# Altium schematic sheet ArduinoUnoShield.SchDoc(ArduinoUnoShield)
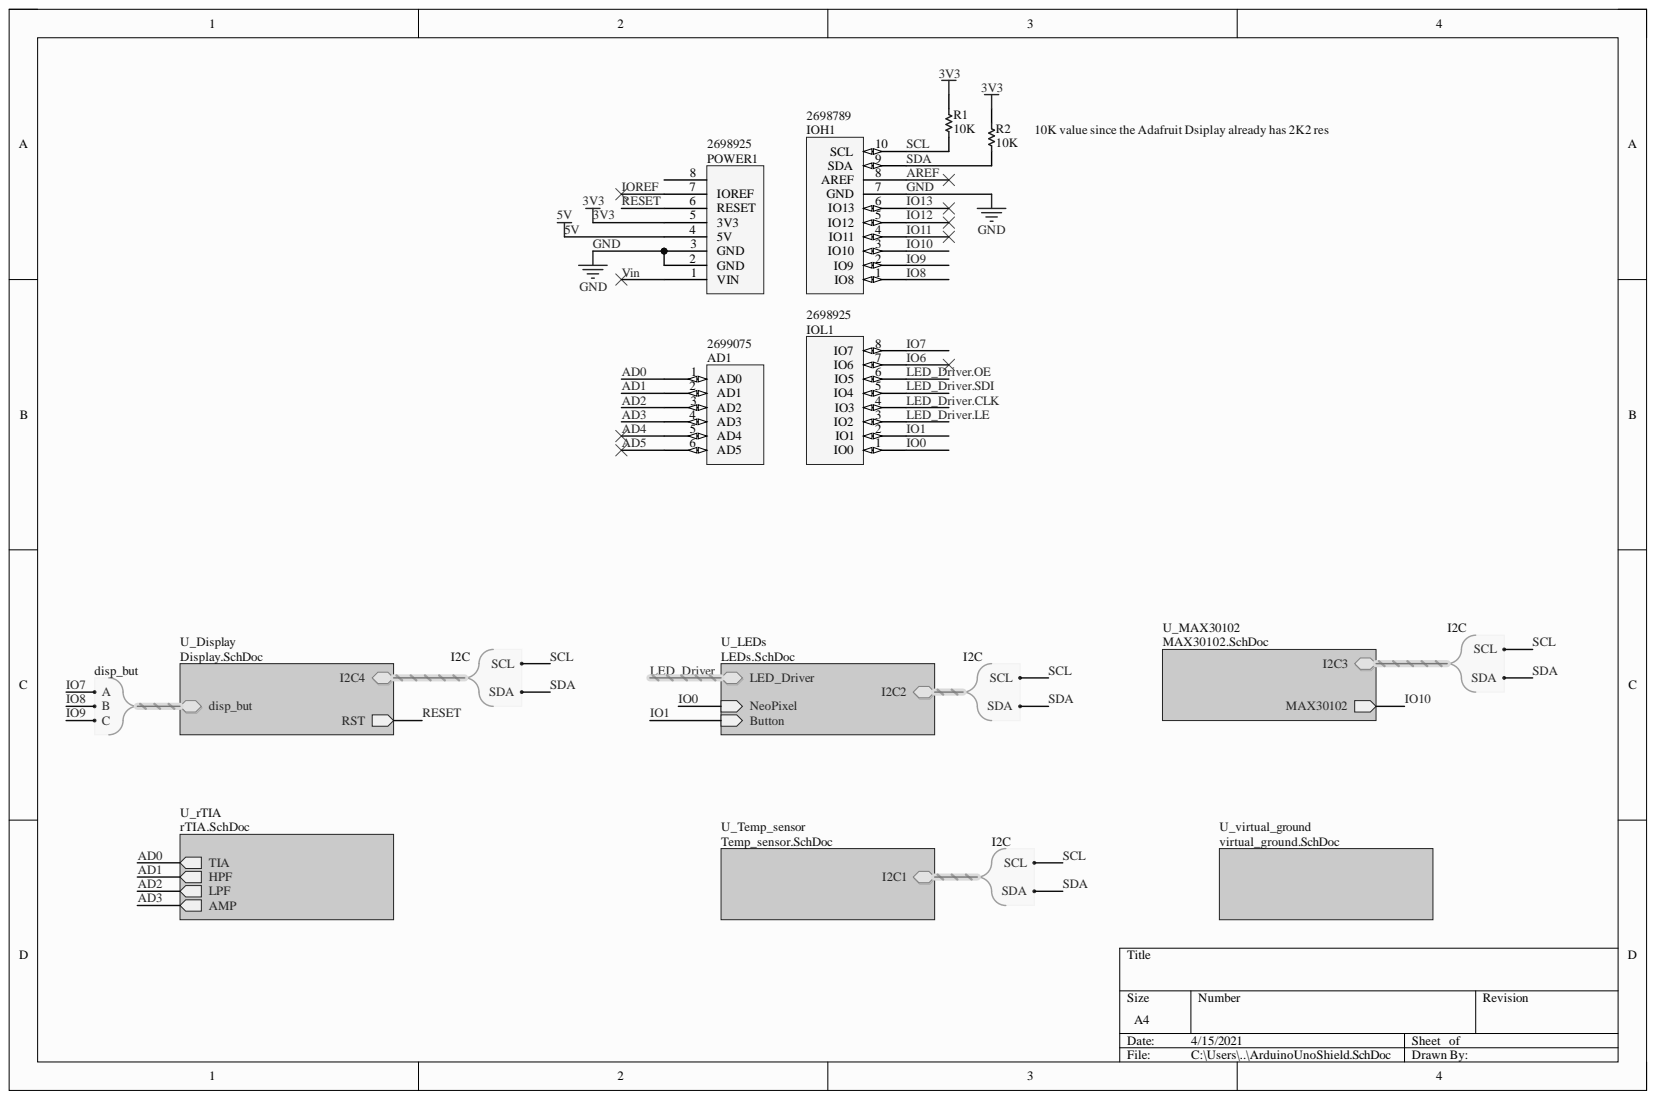
[diagram p0: ArduinoUnoShield.SchDoc]

## Parts
AD1  AD  Supplier Part Number 4: 2699075  [Header 6x1 8.5mm]
IOH1  IOH  Supplier Part Number 3: 2698789  [Header 10x1 8.5mm]
IOL1  IOL  Supplier Part Number 4: 2698925  [Header 8x1 8.5mm]
POWER1  Supplier Part Number 4: 2698925  [Header 8x1 8.5mm]  (hidden comment: POWER_UNO)
R1  10K  [RESC1609X50X30NL8T20]
R2  10K  [RESC1609X50X30NL8T20]

## Nets
3V3: POWER1.5(3V3) R1.2 R2.2
5V: POWER1.4(5V)
AD0: AD1.1(AD0)
AD1: AD1.2
AD2: AD1.3(AD2)
AD3: AD1.4(AD3)
AD4: AD1.5(AD4)
AD5: AD1.6(AD5)
AREF: IOH1.8(AREF)
GND: IOH1.7(GND) POWER1.2(GND) POWER1.3(GND)
IO0: IOL1.1(IO0)
IO1: IOL1.2(IO1)
IO6: IOL1.7(IO6)
IO7: IOL1.8(IO7)
IO8: IOH1.1(IO8)
IO9: IOH1.2(IO9)
IO10: IOH1.3(IO10)
IO11: IOH1.4(IO11)
IO12: IOH1.5(IO12)
IO13: IOH1.6(IO13)
IOREF: POWER1.7(IOREF)
LED_Driver.CLK: IOL1.4(IO3)
LED_Driver.LE: IOL1.3(IO2)
LED_Driver.OE: IOL1.6(IO5)
LED_Driver.SDI: IOL1.5(IO4)
RESET: POWER1.6(RESET)
SCL: IOH1.10(SCL) R1.1
SDA: IOH1.9(SDA) R2.1
Vin: POWER1.1(VIN)
NetPOWER1_8: POWER1.8

## Library data of the parts
R1 R2 = CMP-1666-00005-4: Chip Resistor, 10 KOhm, +/- 1%, 100 mW, -55 to 155 degC, 0603 (1608 Metric), RoHS, Tape and Reel; Manufacturer: Vishay Semiconductor; Voltage Rating: 75 V; Component Kind: Standard; ComponentLink1Description: Manufacturer URL; ComponentLink1URL: http://www.vishay.com/; ComponentLink2Description: Datasheet; ComponentLink2URL: https://datasheet.ciiva.com/11809/0900766b80d98afc-11809037.pdf; DatasheetVersion: 06/2012; Height: 0.45 mm; Length: 1.55 mm; Library Name: Altium Content Vault; Max Operating Temperature: 155 degC; Min Operating Temperature: -55 degC; Mounting Technology: Surface Mount; Package Quantity: 5000; PackageDescription: 2-Pin Surface Mount Device, Body 1.575 x 0.85 mm; PackageReference: 0603; Packaging: Tape and Reel; REACH SVHC: Unknown; RoHSCompliant: true
AD1 = AD: Component Kind: Standard; Library Name: Header.SchLib; Supplier 1: Arrow; Supplier 2: Digi-Key; Supplier 3: Arrow; Supplier 4: Arrow; Supplier Part Number 1: 2699089; Supplier Part Number 2: SAM1198-06-ND; Supplier Part Number 3: 2699088
IOH1 = IOH: Component Kind: Standard; Library Name: Header.SchLib; Supplier 1: Arrow; Supplier 2: Digi-Key; Supplier 3: Arrow; Supplier Part Number 1: 2698803S4475693N1004; Supplier Part Number 2: SAM1198-10-ND
IOL1 = IOL: Component Kind: Standard; Library Name: Header.SchLib; Supplier 1: Digi-Key; Supplier 2: Arrow; Supplier 3: Arrow; Supplier 4: Arrow; Supplier Part Number 1: SAM1198-08-ND; Supplier Part Number 2: 2698939; Supplier Part Number 3: 2698940
POWER1 = POWER_UNO: Component Kind: Standard; Library Name: Header.SchLib; Supplier 1: Digi-Key; Supplier 2: Arrow; Supplier 3: Arrow; Supplier 4: Arrow; Supplier Part Number 1: SAM1198-08-ND; Supplier Part Number 2: 2698939; Supplier Part Number 3: 2698940
